# Altium schematic sheet schematic.SchDoc(schematic)
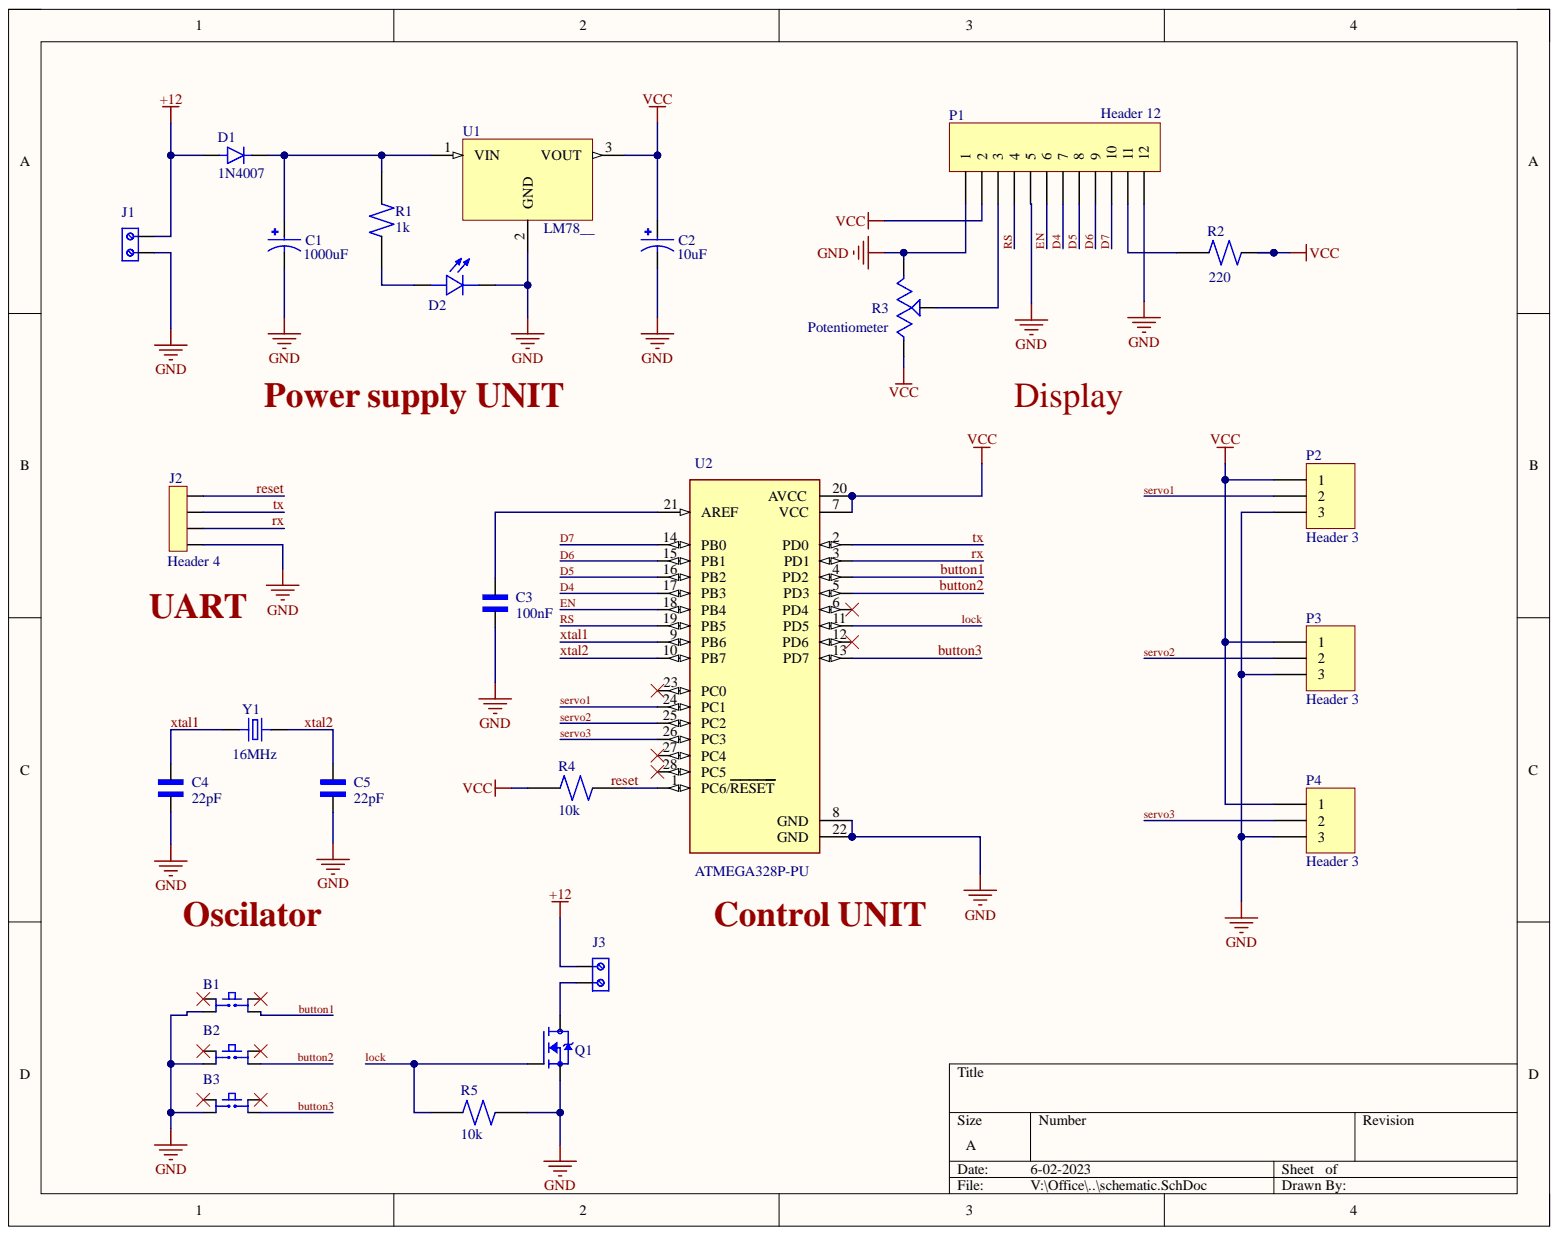
[diagram p0: schematic.SchDoc]

## Parts
B1  Push button  [SW_TS02-66-95-BK-260-LCR-D]  (value not drawn)
B2  Push button  [SW_TS02-66-95-BK-260-LCR-D]  (value not drawn)
B3  Push button  [SW_TS02-66-95-BK-260-LCR-D]  (value not drawn)
C1  1000uF  [CAPPRD500W50D500H1100]
C2  10uF  [CAPPRD500W50D500H1100]
C3  100nF  [CAPRB500W50L450T300H550]
C4  22pF  [CAPRB500W50L450T300H550]
C5  22pF  [CAPRB500W50L450T300H550]
D1  1N4007  [DIOAD1060W80L520D270]
D2  L08R5000Q1  [LEDRD254W57D500H1070]  (value not drawn)
J1  691111710002  [691111710002]  (value not drawn)
J2  Header 4  [SULLINS_PPTC041LFBN-RC]
J3  691111710002  [691111710002]  (value not drawn)
P1  Header 12  [HDR1X12]
P2  Header 3  [HDR1X3]
P3  Header 3  [HDR1X3]
P4  Header 3  [HDR1X3]
Q1  N-Channel mosfet  [TO254P1052X465X1989-3]  (value not drawn)
R1  1k  [RESAD1100W55L680D260]
R2  220  [RESAD1100W55L680D260]
R3  Potentiometer  [TRIM_3306F-1-105]
R4  10k  [RESAD1100W55L680D260]
R5  10k  [RESAD1100W55L680D260]
U1  LM78__  [TO255P1020X450X1968-3]
U2  ATMEGA328P-PU  [DIP794W46P254L2967H457Q28B]
Y1  16MHz  [XTAL_ECS-160-20-4X]

## Nets
+12: D1.A J1.2 J3.1
GND: B1.2 B2.2 B3.2 C1.N C2.N C3.1 C4.1 C5.1 D2.C J1.1 J2.4 P1.1 P1.5 P1.12 P2.3 P3.3 P4.3 Q1.3 R3.3 R5.1 U1.2 U2.8(GND) U2.22(GND)
VCC: C2.P P1.2 P2.1 P3.1 P4.1 R2.1 R3.1 R4.1 U1.3 U2.7(VCC) U2.20(AVCC)
button1: B1.4 U2.4(PD2)
button2: B2.4 U2.5(PD3)
button3: B3.4 U2.13(PD7)
D4: P1.7 U2.17(PB3)
D5: P1.8 U2.16(PB2)
D6: P1.9 U2.15(PB1)
D7: P1.10 U2.14(PB0)
EN: P1.6 U2.18(PB4)
lock: Q1.1 R5.2 U2.11(PD5)
reset: J2.1 R4.2 U2.1(PC6/RESET)
RS: P1.4 U2.19(PB5)
rx: J2.3 U2.3(PD1)
servo1: P2.2 U2.24(PC1)
servo2: P3.2 U2.25(PC2)
servo3: P4.2 U2.26(PC3)
tx: J2.2 U2.2(PD0)
xtal1: C4.2 U2.9(PB6) Y1.2
xtal2: C5.2 U2.10(PB7) Y1.1
NetC1_P: C1.P D1.C R1.2 U1.1
NetC3_2: C3.2 U2.21(AREF)
NetD2_A: D2.A R1.1
NetJ3_2: J3.2 Q1.2
NetP1_3: P1.3 R3.2
NetP1_11: P1.11 R2.2

Not connected: B1 1 3; B2 1 3; B3 1 3; U2 6(PD4) 12(PD6) 23(PC0) 27(PC4) 28(PC5)

## Library data of the parts
C1 C2 = Electrolytic capacitor: FS-A; MF: {'manufacturer': u'Panasonic'}; MP: EEUFS0J221B; Availability: Unavailable; Component Kind: Standard; Package: None; Price: None; Library Name: Installed library.IntLib
C3-C5 = Ceramic capacitor: 2200 pF Â±10% 250V Ceramic Capacitor X7R Radial; MF: TDK; MP: FG24X7R2E222KNT06; Check_prices: https://www.snapeda.com/parts/FG24X7R2E222KNT06/TDK/view-part/?ref=eda; Component Kind: Standard; Digi-Key_Part_Number: 445-173386-1-ND; Package: Radial TDK; Purchase-URL: https://pricing.snapeda.com/search/part/FG24X7R2E222KNT06/?ref=eda; SnapEDA_Link: https://www.snapeda.com/parts/FG24X7R2E222KNT06/TDK/view-part/?ref=snap; Library Name: Installed library.IntLib
D1 = 1N4007: Diode Switching 1KV 1A 2-Pin DO-41; Availability: Unavailable; Component Kind: Standard; Library Name: Components.IntLib; MP: 1N4007; Package: None; Price: None
D2 = LED: LED, Orange, Through Hole, T-1 3/4 (5mm), 30 mA, 2.1 V, 635 nm; MF: {'manufacturer': u'LED Technology'}; Availability: Unavailable; Component Kind: Standard; MP: L08R5000Q1; Package: None; Price: None; Library Name: Installed library.IntLib
J1 J3 = Terminal Block - 2Pins: 2 Position Wire to Board Terminal Block Horizontal with Board 0.197 _5.00mm_ Through Hole; MF: Wurth Electronics; Availability: Unavailable; Component Kind: Standard; MP: 691111710002; Package: None; Price: None; SnapEDA_Link: https://www.snapeda.com/parts/691111710002/Wurth%20Electronics%20Inc./view-part/1195092/?ref=snap; Library Name: Installed library.IntLib
J2 = Female header - 4Pins: 4 Position Header Connector Through Hole; MF: Sullins Connector; MP: PPTC041LFBN-RC; Availability: Warning; Check_prices: https://www.snapeda.com/parts/PPTC041LFBN-RC/Sullins%20Connector%20Solutions/view-part/285917/?ref=eda; Component Kind: Standard; Package: None; Price: None; Purchase-URL: https://pricing.snapeda.com/search/part/PPTC041LFBN-RC/?ref=eda; SnapEDA_Link: https://www.snapeda.com/parts/PPTC041LFBN-RC/Sullins%20Connector%20Solutions/view-part/285917/?ref=snap; Library Name: Installed library.IntLib
P1 = Header 12: Header, 12-Pin; Component Kind: Standard; LatestRevisionDate: 17-Jul-2002; LatestRevisionNote: Re-released for DXP Platform.; Library Name: Miscellaneous Connectors.IntLib; Signal Integrity: Connector; Publisher: Altium Limited
P2-P4 = Header 3: Header, 3-Pin; Component Kind: Standard; LatestRevisionDate: 17-Jul-2002; LatestRevisionNote: Re-released for DXP Platform.; Library Name: Miscellaneous Connectors.IntLib; Signal Integrity: Connector; Publisher: Altium Limited
Q1 = IRF540: N-Channel 100V 22A (Tc) 85W (Tc) Through Hole TO-220AB; Availability: Unavailable; Component Kind: Standard; MP: IRF540; Package: None; Price: None; Library Name: Installed library.IntLib
R1 R2 R4 R5 = Resistor (0.25W): (MFR-25FBF52-10K) 10,2 kOhm bei 1% 0,25W, 1/4W Durchbohrlochwiderstand Axial Metal Film; MF: Yageo; Component Kind: Standard; Digi-Key_Part_Number: 10.2KXBK-ND; MP: MFR-25FBF52-10K; Package: Axial Yageo; Purchase-URL: https://pricing.snapeda.com/search/part/MFR-25FBF52-10K/?ref=eda; SnapEDA_Link: https://www.snapeda.com/parts/MFR-25FBF52-10K/Yageo/view-part/424333/?ref=snap; Library Name: Installed library.IntLib
R3 = Potentiometer1: (3306F-1-105) 1 MOhms 0.2W, 1/5W PC Pins Through Hole Trimmer Potentiometer Cermet 1 Turn Top Adjustment; MF: Bourns; Availability: Good; Check_prices: https://www.snapeda.com/parts/3306F-1-105/Bourns%20-%20J.W.%20Miller/view-part/862876/?ref=eda; Component Kind: Standard; MP: 3306F-1-105; Package: 3306F Bourns; Price: None; Purchase-URL: https://pricing.snapeda.com/search/part/3306F-1-105/?ref=eda; SnapEDA_Link: https://www.snapeda.com/parts/3306F-1-105/Bourns%20-%20J.W.%20Miller/view-part/862876/?ref=snap; Library Name: Installed library.IntLib
U1 = LM78__: MP: LM7805CT/NOPB; Availability: Unavailable; Component Kind: Standard; Library Name: Voltage regulator.IntLib; Package: None; Price: None
U2 = ATMEGA328P-PU: ATmega Series 20 MHz 32 KB Flash 2 KB SRAM 8-Bit Microcontroller - DIP-28; Availability: Unavailable; Component Kind: Standard; MP: ATMEGA328P-PU; Package: None; Price: None; Library Name: Installed library.IntLib
Y1 = Crystal oscilator: CRYSTAL T/H; MF: {'manufacturer': u'ECS Inc.'}; MP: ECS-160-S-4; Availability: Unavailable; Component Kind: Standard; Package: None; Price: None; Library Name: Installed library.IntLib
B1-B3 = Push button: Component Kind: Standard; Library Name: Installed library.IntLib
